# Altium schematic sheet ShapeBot.SchDoc(ShapeBot)
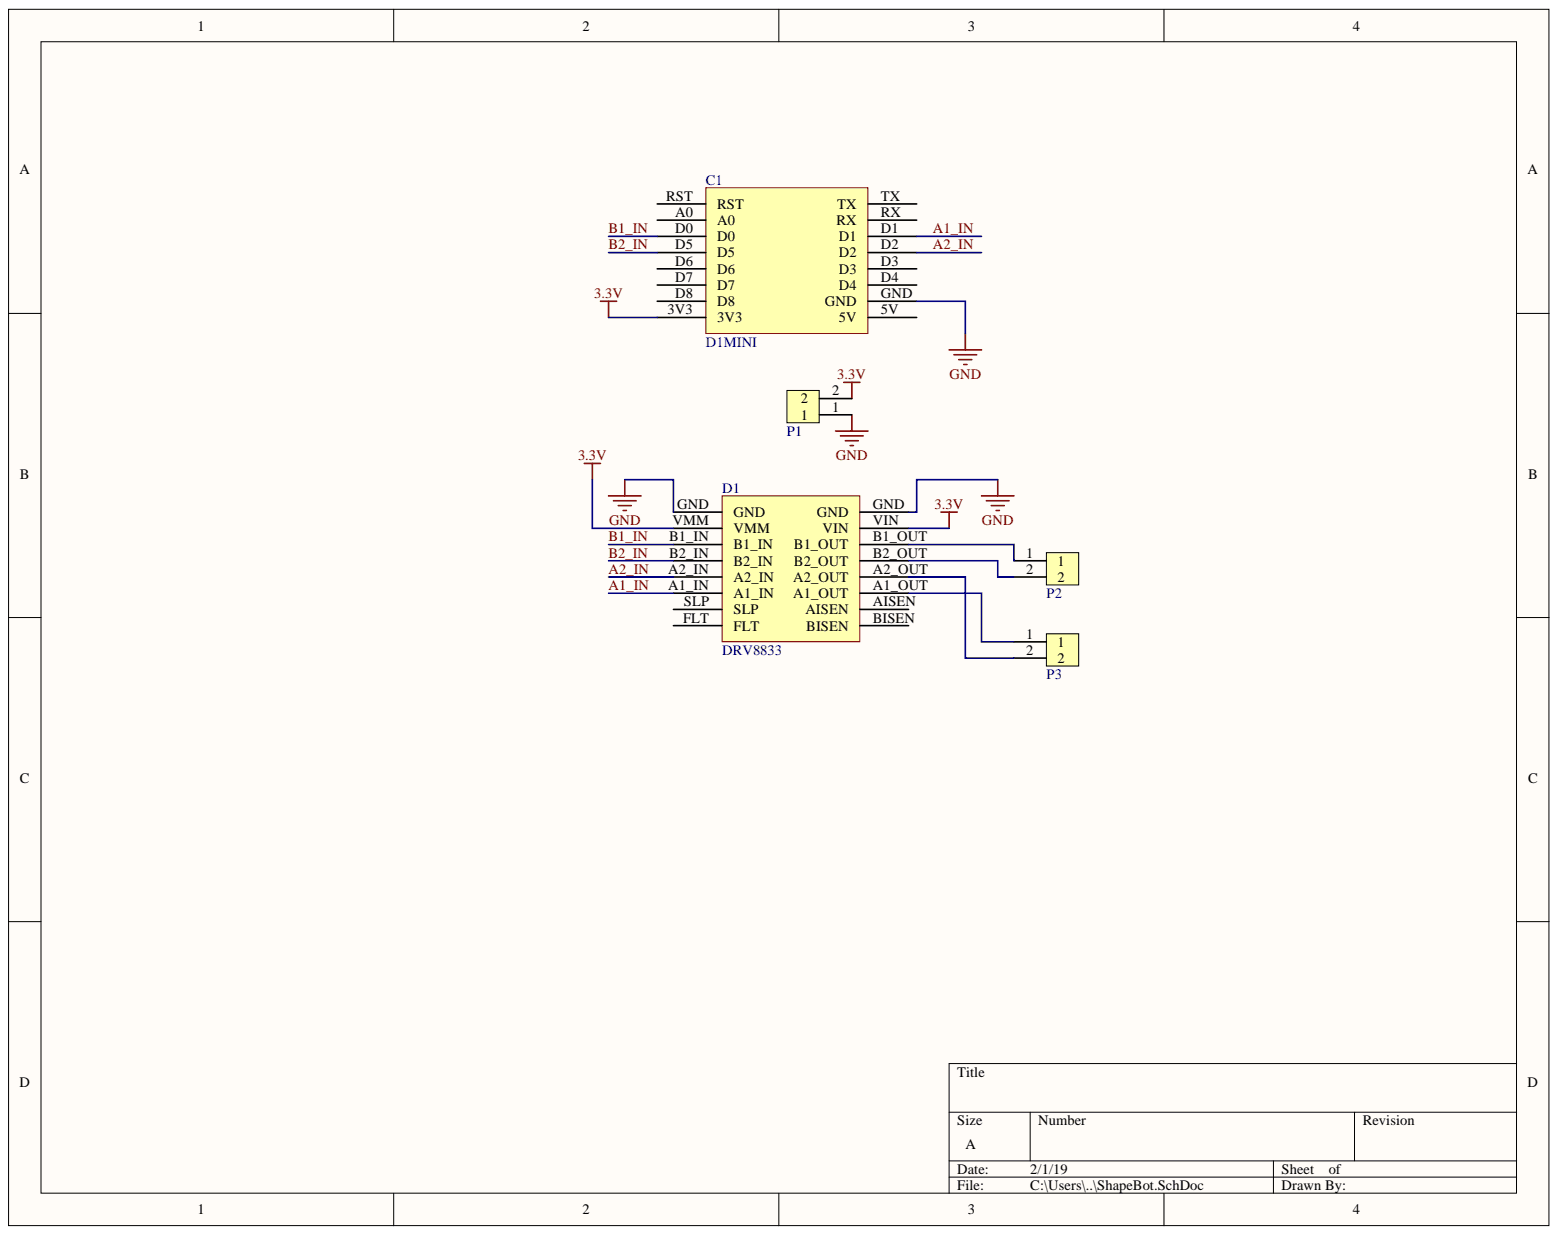
[diagram p0: ShapeBot.SchDoc]

## Parts
C1  D1MINI  [D1MINI]
D1  DRV8833  [DRV8833]
P1  HEADER 2X1 0.1" UNPOLARIZED  [HEADER 2X1 0.1" - UNPOLARIZED]  (value not drawn)
P2  HEADER 2X1 0.1" UNPOLARIZED  [HEADER 2X1 0.1" - UNPOLARIZED]  (value not drawn)
P3  HEADER 2X1 0.1" UNPOLARIZED  [HEADER 2X1 0.1" - UNPOLARIZED]  (value not drawn)

## Nets
3.3V: C1.3V3 D1.VIN D1.VMM P1.2
GND: C1.GND D1.GND P1.1
A1_IN: C1.D1 D1.A1_IN
A2_IN: C1.D2 D1.A2_IN
B1_IN: C1.D0 D1.B1_IN
B2_IN: C1.D5 D1.B2_IN
NetD1_A1_OUT: D1.A1_OUT P3.1
NetD1_A2_OUT: D1.A2_OUT P3.2
NetD1_B1_OUT: D1.B1_OUT P2.1
NetD1_B2_OUT: D1.B2_OUT P2.2

Not connected: C1 5V A0 D3 D4 D6 D7 D8 RST RX TX; D1 AISEN BISEN FLT SLP

## Library data of the parts
C1 = D1MINI: Library Name: SBComponentsSchematics.SchLib; Component Kind: Standard
D1 = DRV8833: Library Name: SBComponentsSchematics.SchLib; Component Kind: Standard
P1-P3 = HEADER 2X1 0.1" UNPOLARIZED: Library Name: SBComponentsSchematics.SchLib; Component Kind: Standard
